# Altium schematic sheet LED_low_side_control.SchDoc("Low Power LED Module")
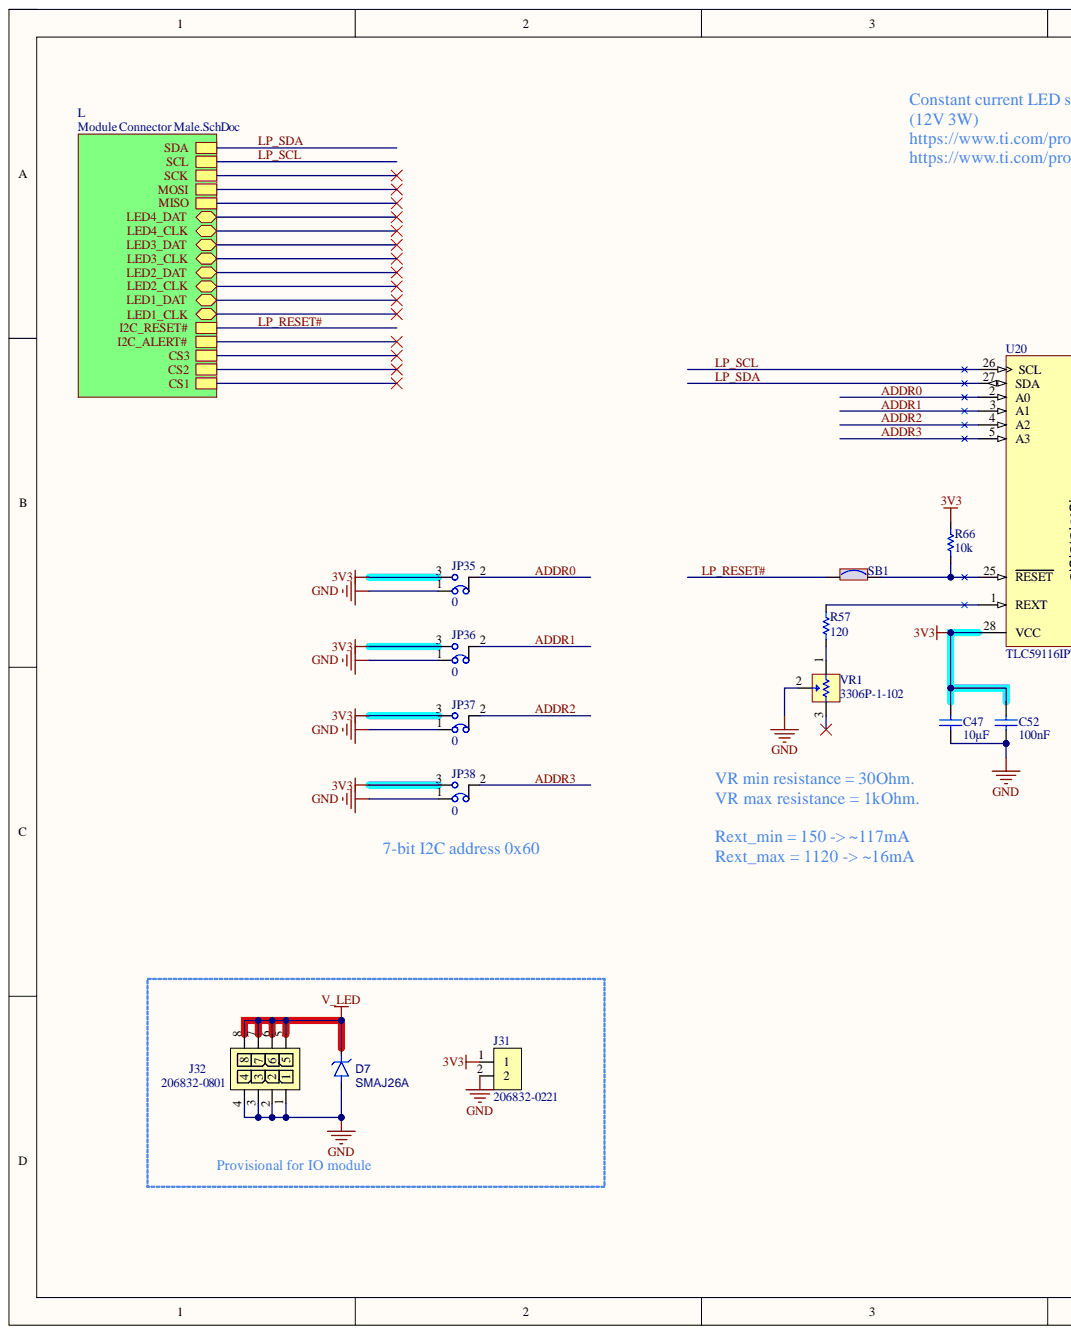
[diagram p0: LED_low_side_control.SchDoc, left]
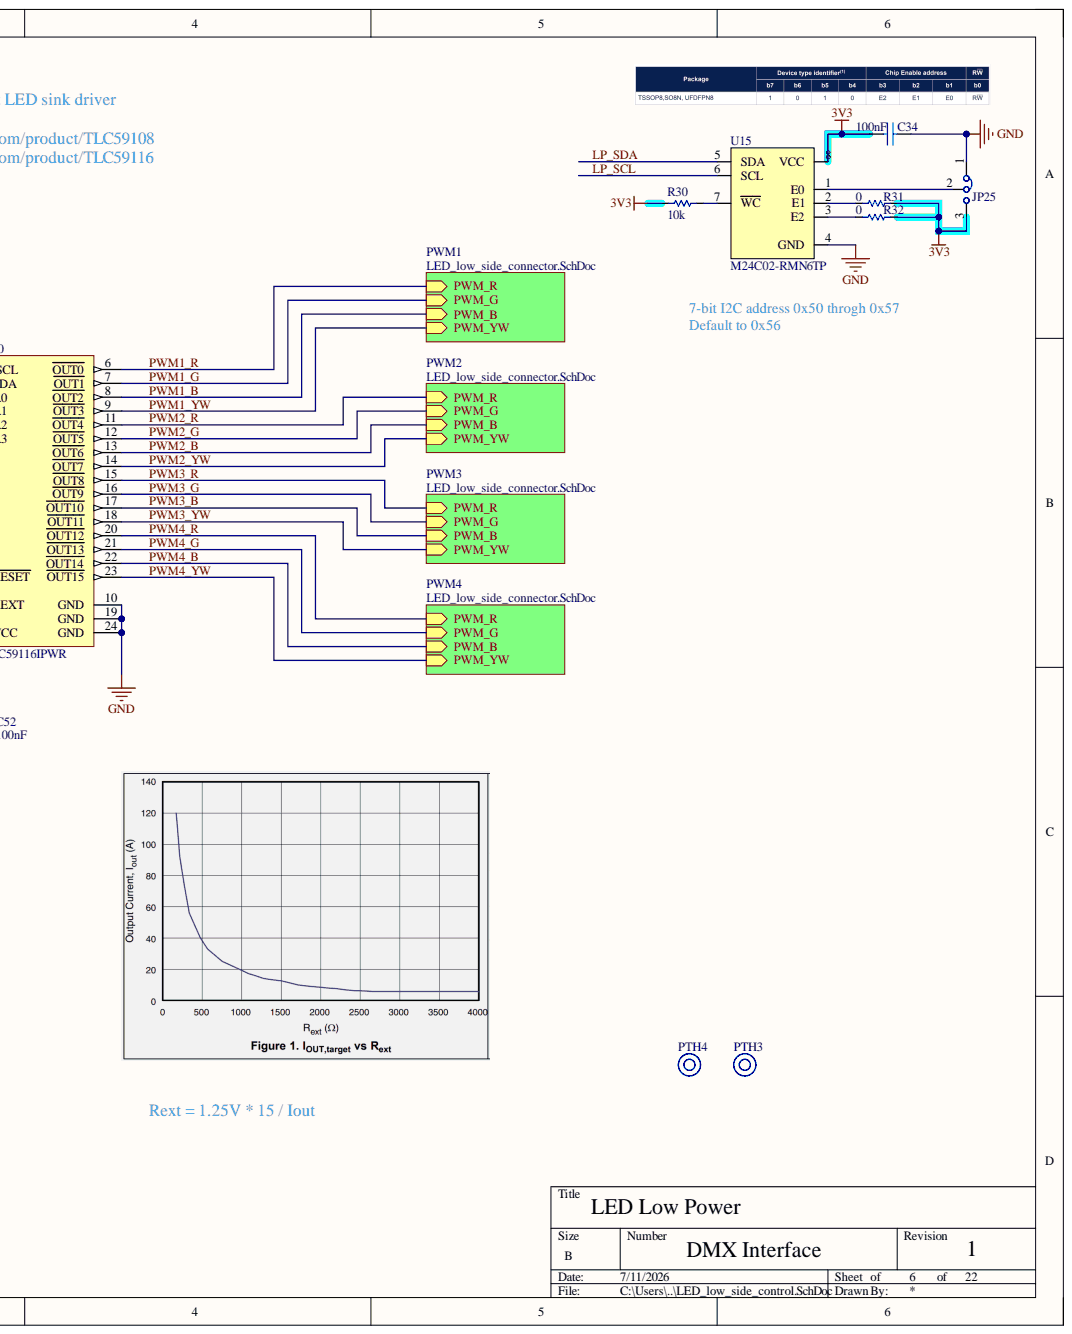
[diagram p1: LED_low_side_control.SchDoc, right]

## Parts
C34  value 100nF  [CAPC1608X10N_0603_MED]  (hidden comment: CC0603KRX7R0BB104)
C47  value 10µF  [CAPC2012X14N_0805_MED]  (hidden comment: GRM21BC81C106KA73L)
C52  value 100nF  [CAPC1608X10N_0603_MED]  (hidden comment: CC0603KRX7R0BB104)
D7  SMAJ26A  [FP-SMAJ-MFG]
J31  206832-0221  [206832-0221-Footprint-1]
J32  206832-0801  [206832-0801-Footprint-1]
JP25  Jumper 3 x 1 Bridge 1-2 Solder Bridge  [Jumper 3 Solder Bridge 1-2 0603]  (value not drawn)
JP35  value 0  [Jumper 3 Solder Bridge 1-2 0603]  (hidden comment: Jumper 3 x 1 Bridge 1-2 Solder Bridge)
JP36  value 0  [Jumper 3 Solder Bridge 1-2 0603]  (hidden comment: Jumper 3 x 1 Bridge 1-2 Solder Bridge)
JP37  value 0  [Jumper 3 Solder Bridge 1-2 0603]  (hidden comment: Jumper 3 x 1 Bridge 1-2 Solder Bridge)
JP38  value 0  [Jumper 3 Solder Bridge 1-2 0603]  (hidden comment: Jumper 3 x 1 Bridge 1-2 Solder Bridge)
PTH3  Mounting Hole NPTH  [Mounting Hole M3 No Washer NPTH]  (value not drawn)  (no BOM)
PTH4  Mounting Hole NPTH  [Mounting Hole M3 No Washer NPTH]  (value not drawn)  (no BOM)
R30  value 10k  [RESC1608X06N_0603_MED]  (hidden comment: RC0603FR-1010KL)
R31  value 0  [RESC1608X06N_0603_MED]  (hidden comment: RC0603FR-070RL)
R32  value 0  [RESC1608X06N_0603_MED]  (hidden comment: RC0603FR-070RL)
R57  value 120  [RESC1608X06N_0603_MED]  (hidden comment: RC0603FR-07120RL)
R66  value 10k  [RESC1608X06N_0603_MED]  (hidden comment: RC0603FR-1010KL)
SB1  SolderBridge0603_On  [SOLDER-BRIDGE_R0603-0R]  (value not drawn)
U15  M24C02-RMN6TP  [M24C02-RMN6TP-SO8N-IPC_B]
U20  TLC59116IPWR  [PW0028A_L]
VR1  3306P-1-102  [3306P-1-102-Footprint-1]

## Nets
3V3: C34.1 C47.2 C52.1 J31.1 JP25.3 JP35.3 JP36.3 JP37.3 JP38.3 R30.2 R31.1 R32.1 R66.2 U15.8(VCC) U20.28(VCC)
GND: C34.2 C47.1 C52.2 D7.2 J31.2 J32.1 J32.2 J32.3 J32.4 JP25.1 JP35.1 JP36.1 JP37.1 JP38.1 U15.4(GND) U20.10(GND) U20.19(GND) U20.24(GND) VR1.2
V_LED: D7.1 J32.5 J32.6 J32.7 J32.8
ADDR0: JP35.2 U20.2(A0)
ADDR1: JP36.2 U20.3(A1)
ADDR2: JP37.2 U20.4(A2)
ADDR3: JP38.2 U20.5(A3)
LP_RESET#: SB1.1
LP_SCL: U15.6(SCL) U20.26(SCL)
LP_SDA: U15.5(SDA) U20.27(SDA)
PWM1_B: U20.8(OUT2)
PWM1_G: U20.7(OUT1)
PWM1_R: U20.6(OUT0)
PWM1_YW: U20.9(OUT3)
PWM2_B: U20.13(OUT6)
PWM2_G: U20.12(OUT5)
PWM2_R: U20.11(OUT4)
PWM2_YW: U20.14(OUT7)
PWM3_B: U20.17(OUT10)
PWM3_G: U20.16(OUT9)
PWM3_R: U20.15(OUT8)
PWM3_YW: U20.18(OUT11)
PWM4_B: U20.22(OUT14)
PWM4_G: U20.21(OUT13)
PWM4_R: U20.20(OUT12)
PWM4_YW: U20.23(OUT15)
NetJP25_2: JP25.2 U15.1(E0)
NetR30_1: R30.1 U15.7(WC)
NetR31_2: R31.2 U15.2(E1)
NetR32_2: R32.2 U15.3(E2)
NetR57_1: R57.1 VR1.1
NetR57_2: R57.2 U20.1(REXT)
NetR66_1: R66.1 SB1.2 U20.25(RESET)
NetVR1_3: VR1.3
CS1:
CS2:
CS3:
I2C_ALERT#:
LED1_CLK:
LED1_DAT:
LED2_CLK:
LED2_DAT:
LED3_CLK:
LED3_DAT:
LED4_CLK:
LED4_DAT:
MISO:
MOSI:
SCK:

## Library data of the parts
C34 C52 = CMP-001-00102-4: Ceramic Capacitor, Multilayer, Ceramic, 100V, 10% +Tol, 10% -Tol, X7R, 15% TC, 0.1uF, Surface Mount, 0603; Capacitor Type: Ceramic; Case/Package: 0603; Component Kind: Standard; JLCPN: C113803; Max Operating Temperature: 125°C; Min Operating Temperature: -55°C; Mounting Technology: Surface Mount; Pins: 2; RoHS Compliant: Yes; Voltage Rating: 100V; Library Name: Equus Computer Systems
C47 = CMP-001-00084-4: Ceramic Capacitor, Multilayer, Ceramic, 10uF, 16V, 10%, X6S, 0805; Capacitor Type: Ceramic; Case/Package: 0805; Component Kind: Standard; JLCPN: C162413; Max Operating Temperature: 105°C; Min Operating Temperature: -55°C; Mounting Technology: Surface Mount; Pins: 2; RoHS Compliant: Yes; Tolerance: 10%; Voltage Rating: 16V; Library Name: Equus Computer Systems
D7 = CMP-004-00046-2: Trans Voltage Suppressor Diode, 400W, 26V V(RWM), Unidirectional, 1 Element, Silicon, DO-214AC; Component Kind: Standard; JLCPN: C148225; Max Operating Temperature: 150°C; Min Operating Temperature: -65°C; Pins: 2; RoHS Compliant: Yes; Library Name: Equus Computer Systems
J31 = CMP-002-00210-4: Micro-Fit+, Vertial Header, 2 Position 3mm pitch; Gender: Male; Max Current Rating: 12.5A; Component Kind: Standard; Connector Type: Micro-Fit+; Pins: 2; Library Name: Equus Computer Systems
J32 = CMP-002-00208-6: Micro-Fit+, Vertical Header, 8 pin; Max Current Rating: 12.5A; Component Kind: Standard; Connector Type: Micro-Fit+; Mounting Technology: Through Hole; Pins: 8; Library Name: Equus Computer Systems
JP25 = CMP-009-00341-2: 0603 Solder Bridge Jumper Surface Mount; Tolerance: 1%; Value: 0; Voltage Rating: 75V; Case/Package: 0603; Component Kind: Standard; Max Operating Temperature: 155°C; Min Operating Temperature: -55°C; Mounting Technology: Surface Mount; Pins: 2; RoHS Compliant: Yes; Library Name: Equus Computer Systems
JP35-JP38 = CMP-009-00341-2: 0603 Solder Bridge Jumper Surface Mount; Tolerance: 1%; Voltage Rating: 75V; Case/Package: 0603; Component Kind: Standard; Max Operating Temperature: 155°C; Min Operating Temperature: -55°C; Mounting Technology: Surface Mount; Pins: 2; RoHS Compliant: Yes; Library Name: Equus Computer Systems
PTH3 PTH4 = CMP-00028-00043-3: Description: Mounting Hole NPTH; Library Name: Equus Computer Systems
R30 R66 = CMP-009-00306-2: Fixed Resistor, Metal Glaze/thick Film, 0.1W, 10000ohm, 75V, 1% +/-Tol, 100ppm/Cel, Surface Mount, 0603; Power: 100mW; Voltage Rating: 50V; Case/Package: 0603; Component Kind: Standard; JLCPN: C98220; Max Operating Temperature: 155°C; Min Operating Temperature: -55°C; Mounting Technology: Surface Mount; Pins: 2; RoHS Compliant: Yes; Tolerance: 1%; Library Name: Equus Computer Systems
R31 R32 = CMP-009-00204-3: RC Series 0603 0.1 W 0 Ohm Jumper Surface Mount Thick Film Chip Resistor; Power: 100mW; Tolerance: 1%; Voltage Rating: 75V; Case/Package: 0603; Component Kind: Standard; JLCPN: C100044; Max Operating Temperature: 155°C; Min Operating Temperature: -55°C; Mounting Technology: Surface Mount; Pins: 2; RoHS Compliant: Yes; Library Name: Equus Computer Systems
R57 = CMP-009-00303-2: Res General Purpose Thick Film 0603 120 Ohm 1% 1/10W ±100ppm/°C Molded SMD Paper T/R; Power: 100mW; Voltage Rating: 50V; Case/Package: 0603; Component Kind: Standard; JLCPN: C114640; Max Operating Temperature: 155°C; Min Operating Temperature: -55°C; Mounting Technology: Surface Mount; Pins: 2; RoHS Compliant: Yes; Tolerance: 1%; Library Name: Equus Computer Systems
SB1 = CMP-128-000020-6: Solder Bridge ON; Internal_Part_Number: MCD-00071; Manufacturer: YAGEO; Manufacturer_Part_Number: RC0603JR-070RL; Part_Type: Mechanical; Provided_By: SUBCO; Component Kind: Standard; ComponentLink1Description: Datasheet; ComponentLink1URL: http://tunmcdsvn.tun.st.com/Component_Datasheet_Library/Trunk/Resistors/Yageo_Resistor_RC0603-series; Design Item ID: SolderBridge0603_On; ECCN_EU: n/a; ECCN_US: n/a; JLCPN: C100044; Package: SB0603; Source: MB1404.SCHLIB; Status: Released; Library Name: Equus Computer Systems
U15 = CMP-019-00078-2: M24C02 Series 2 Kb (256 x 8) 1.8 V SMT Serial I²C Bus EEPROM - SOIC-8; Clock Frequency: 400kHz; Max Supply Voltage: 5.5V; Memory Size: 256B; Min Supply Voltage: 1.8V; Case/Package: SOIC; Component Kind: Standard; Frequency: 400kHz; JLCPN: C83836; Max Operating Temperature: 85°C; Memory Format: EEPROM; Min Operating Temperature: -40°C; Mounting Technology: Surface Mount; Pins: 8; Library Name: Equus Computer Systems
U20 = CMP-00024-00008-2: LED Driver, 16-Segment, PDSO28; Nominal Supply Voltage: 3.3V; Case/Package: TSSOP; Component Kind: Standard; JLCPN: C131194; Max Operating Temperature: 85°C; Min Operating Temperature: -40°C; Pins: 28; RoHS Compliant: Yes; Library Name: Equus Computer Systems
VR1 = CMP-00028-00042-1: Trimmer, Cermet, 0.2W, 1000ohm, 100V, 25% +/-Tol, 250ppm/Cel, 1 Turn(s); Component Kind: Standard; Max Operating Temperature: 100°C; Min Operating Temperature: -25°C; Mounting Technology: Through Hole; Pins: 3; RoHS Compliant: Yes; Library Name: Equus Computer Systems
